# Altium schematic sheet Capstone_Schematic_24.SchDoc
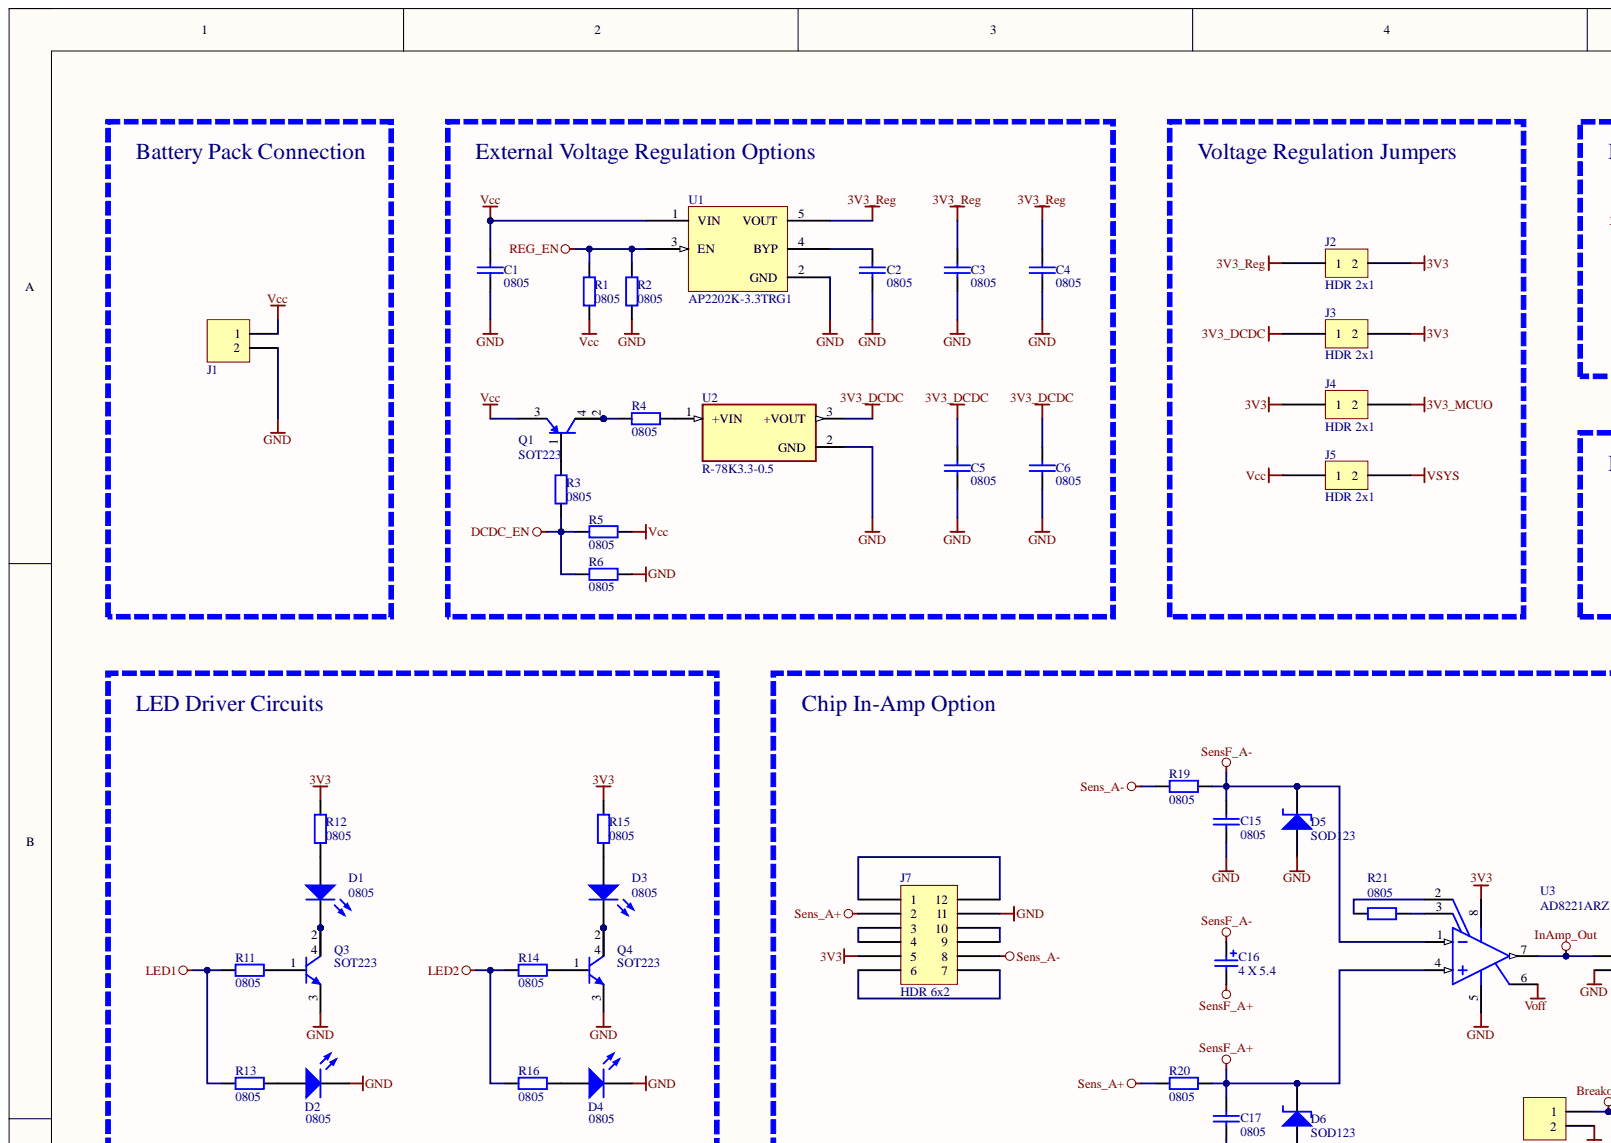
[diagram p0: Capstone_Schematic_24.SchDoc, top left]
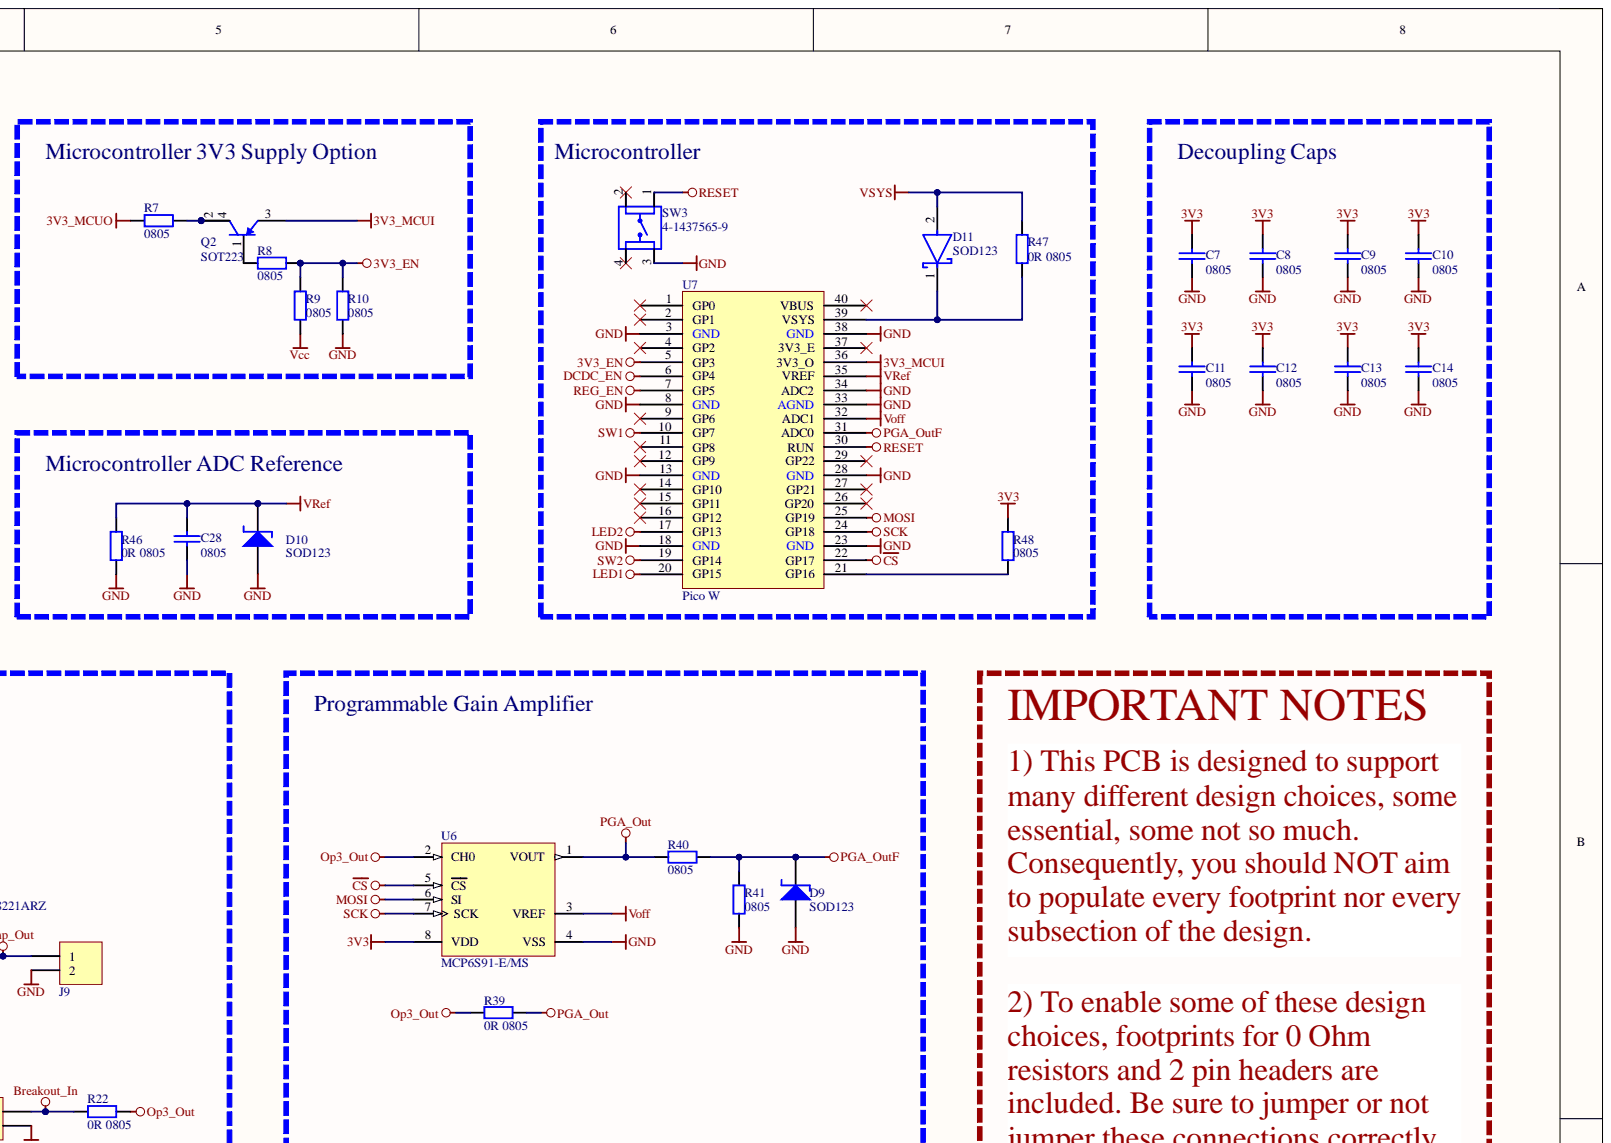
[diagram p1: Capstone_Schematic_24.SchDoc, top right]
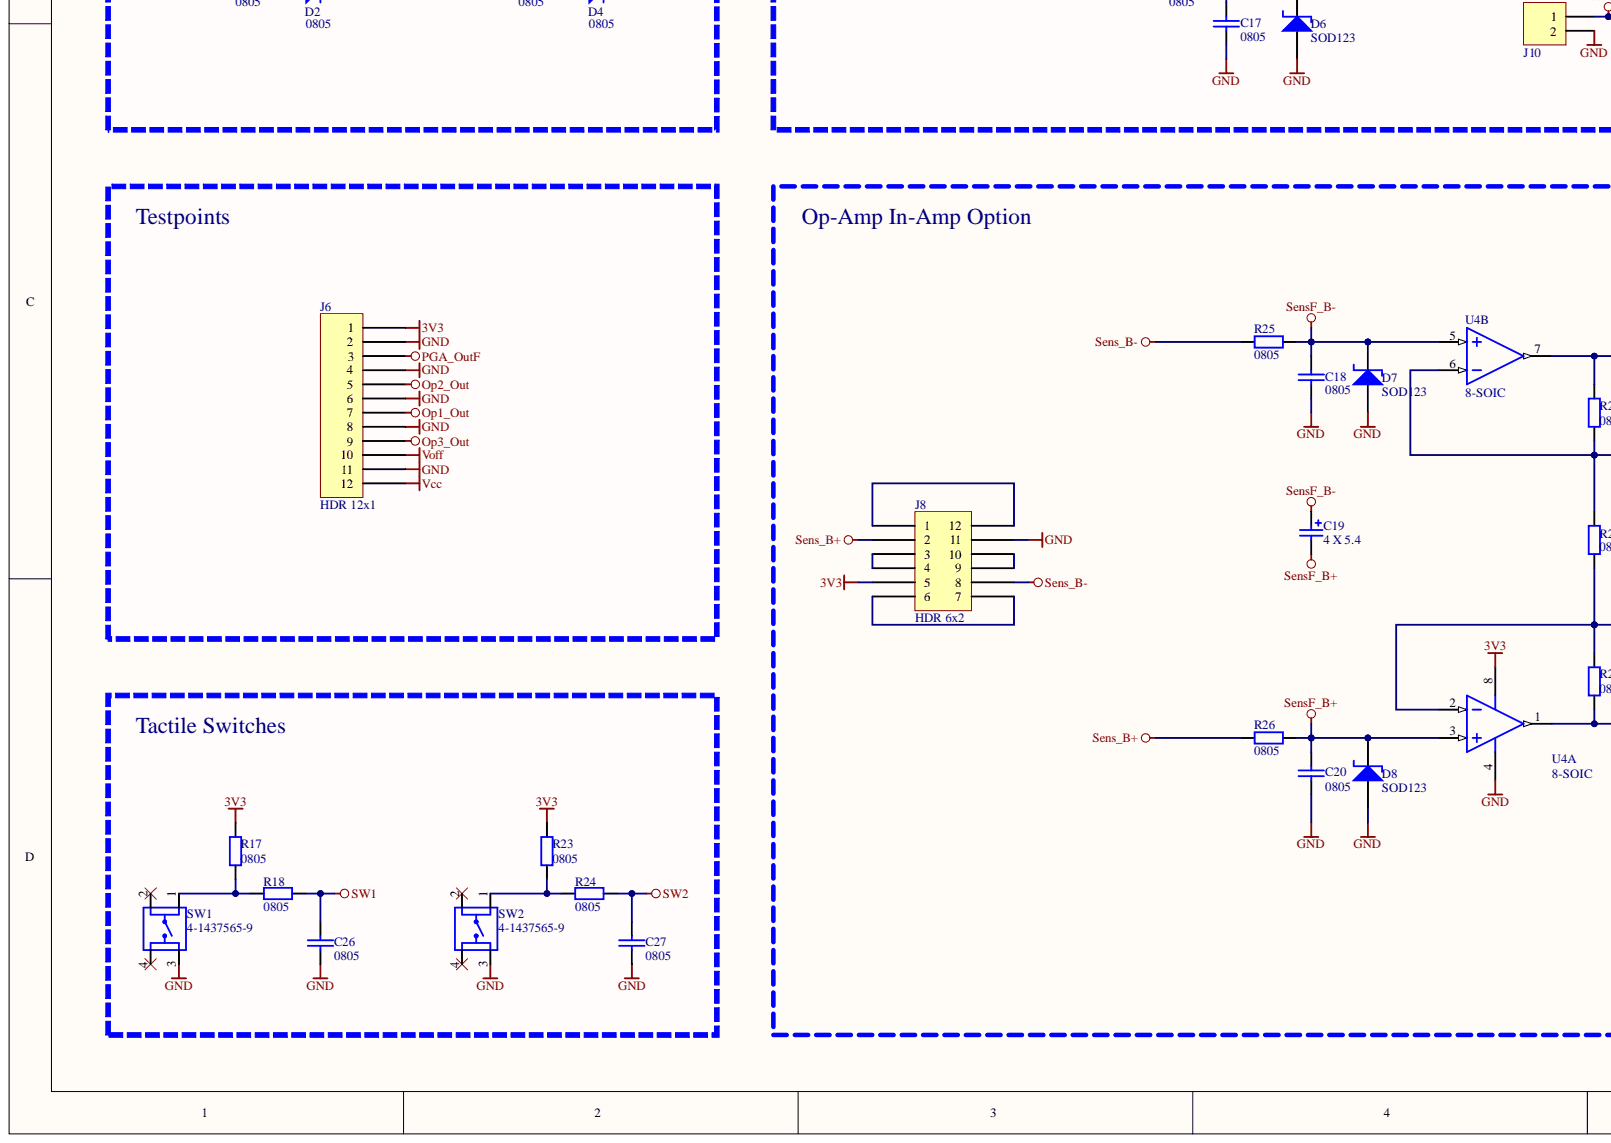
[diagram p2: Capstone_Schematic_24.SchDoc, bottom left]
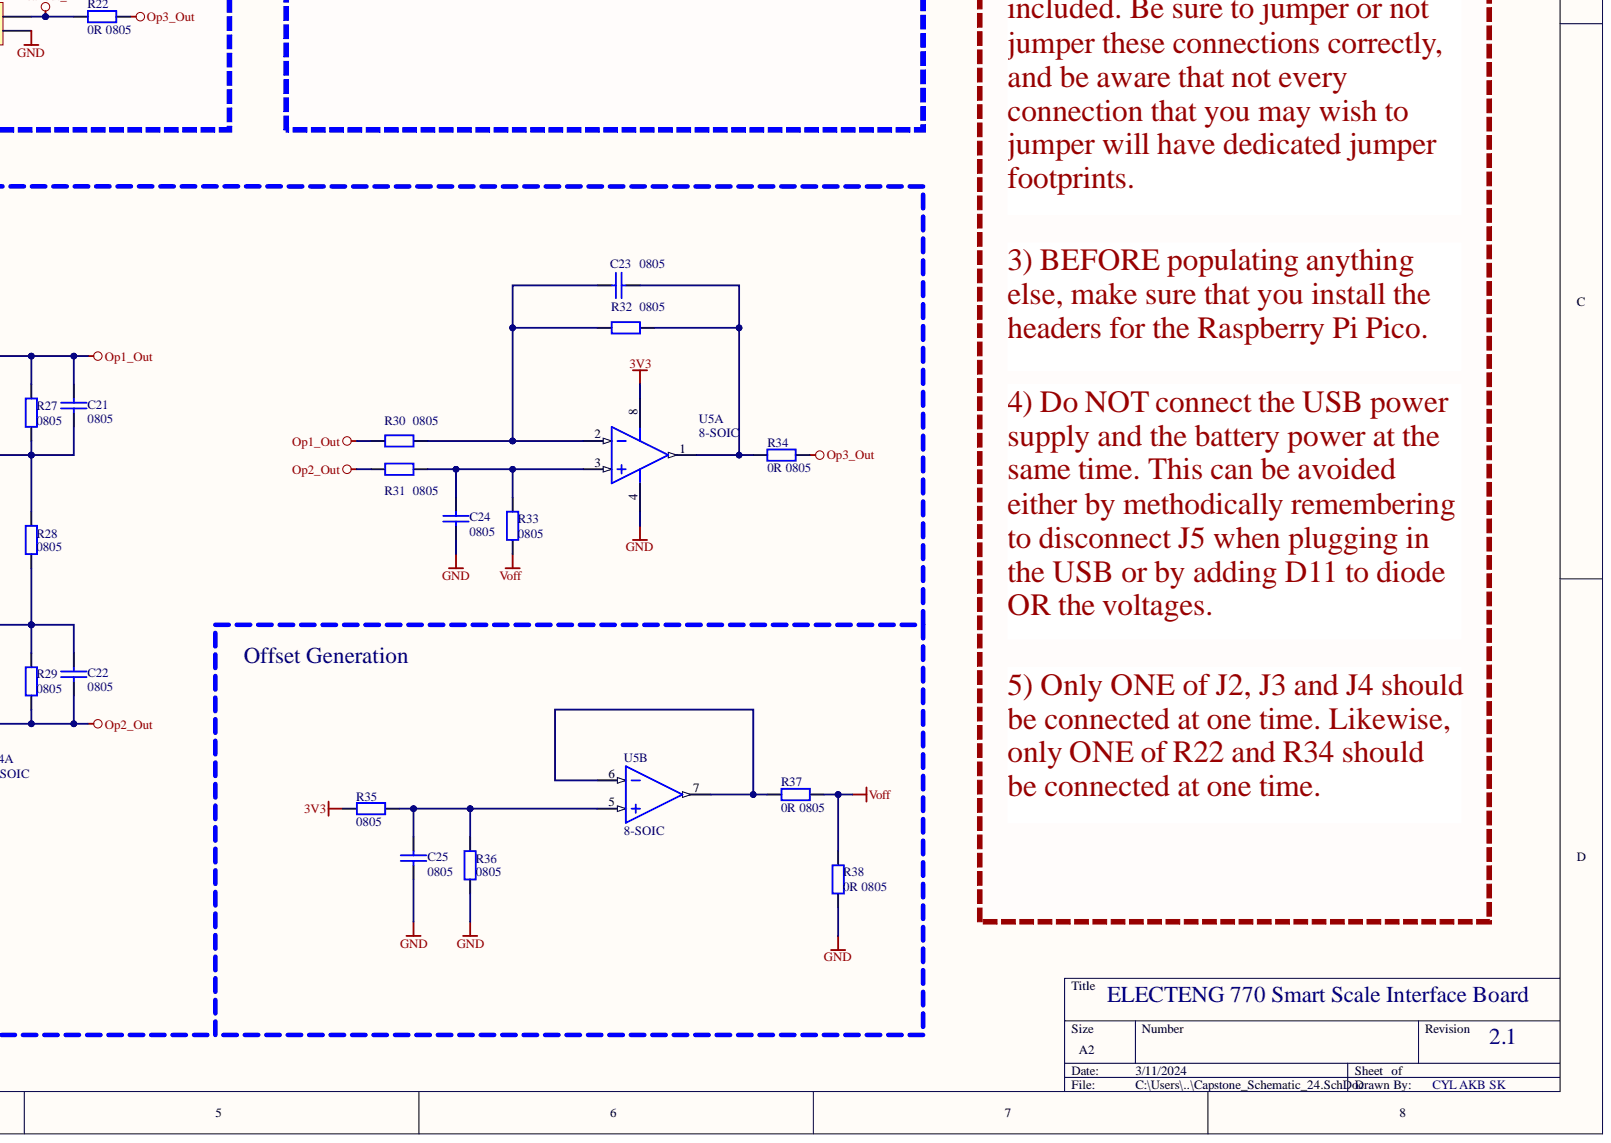
[diagram p3: Capstone_Schematic_24.SchDoc, bottom right]

## Parts
C1  0805  [0805 CAP]
C2  0805  [0805 CAP]
C3  0805  [0805 CAP]
C4  0805  [0805 CAP]
C5  0805  [0805 CAP]
C6  0805  [0805 CAP]
C7  0805  [0805 CAP]
C8  0805  [0805 CAP]
C9  0805  [0805 CAP]
C10  0805  [0805 CAP]
C11  0805  [0805 CAP]
C12  0805  [0805 CAP]
C13  0805  [0805 CAP]
C14  0805  [0805 CAP]
C15  0805  [0805 CAP]
C16  Size/Package: 4 X 5.4  [ECE-CAPAE4X5.4SM]  (hidden comment: 1uF)
C17  0805  [0805 CAP]
C18  0805  [0805 CAP]
C19  Size/Package: 4 X 5.4  [ECE-CAPAE4X5.4SM]  (hidden comment: 1uF)
C20  0805  [0805 CAP]
C21  0805  [0805 CAP]
C22  0805  [0805 CAP]
C23  0805  [0805 CAP]
C24  0805  [0805 CAP]
C25  0805  [0805 CAP]
C26  0805  [0805 CAP]
C27  0805  [0805 CAP]
C28  0805  [0805 CAP]
D1  0805  [0805 LED]
D2  0805  [0805 LED]
D3  0805  [0805 LED]
D4  0805  [0805 LED]
D5  SOD123  [ECE-DIO-SOD123SM]
D6  SOD123  [ECE-DIO-SOD123SM]
D7  SOD123  [ECE-DIO-SOD123SM]
D8  SOD123  [ECE-DIO-SOD123SM]
D9  SOD123  [ECE-DIO-SOD123SM]
D10  SOD123  [ECE-DIO-SOD123SM]
D11  SOD123  [FP-SOD123-MFG]
J1  2 Way  [ECE-600102STTH]  (value not drawn)
J2  HDR 2x1  [HDR 2x1]
J3  HDR 2x1  [HDR 2x1]
J4  HDR 2x1  [HDR 2x1]
J5  HDR 2x1  [HDR 2x1]
J6  HDR 12x1  [HDR 12x1]
J7  HDR 6x2  [HDR 6x2]
J8  HDR 6x2  [HDR 6x2]
J9  2 Way  [ECE-600102STTH]  (value not drawn)
J10  2 Way  [ECE-600102STTH]  (value not drawn)
Q1  SOT223  [ECE-SOT223SM]
Q2  SOT223  [ECE-SOT223SM]
Q3  SOT223  [ECE-SOT223SM]
Q4  SOT223  [ECE-SOT223SM]
R1  0805  [0805 RES]
R2  0805  [0805 RES]
R3  0805  [0805 RES]
R4  0805  [0805 RES]
R5  0805  [0805 RES]
R6  0805  [0805 RES]
R7  0805  [0805 RES]
R8  0805  [0805 RES]
R9  0805  [0805 RES]
R10  0805  [0805 RES]
R11  0805  [0805 RES]
R12  0805  [0805 RES]
R13  0805  [0805 RES]
R14  0805  [0805 RES]
R15  0805  [0805 RES]
R16  0805  [0805 RES]
R17  0805  [0805 RES]
R18  0805  [0805 RES]
R19  0805  [0805 RES]
R20  0805  [0805 RES]
R21  0805  [0805 RES]
R22  0R 0805  [0805 RES]
R23  0805  [0805 RES]
R24  0805  [0805 RES]
R25  0805  [0805 RES]
R26  0805  [0805 RES]
R27  0805  [0805 RES]
R28  0805  [0805 RES]
R29  0805  [0805 RES]
R30  0805  [0805 RES]
R31  0805  [0805 RES]
R32  0805  [0805 RES]
R33  0805  [0805 RES]
R34  0R 0805  [0805 RES]
R35  0805  [0805 RES]
R36  0805  [0805 RES]
R37  0R 0805  [0805 RES]
R38  0R 0805  [0805 RES]
R39  0R 0805  [0805 RES]
R40  0805  [0805 RES]
R41  0805  [0805 RES]
R46  0R 0805  [0805 RES]
R47  0R 0805  [0805 RES]
R48  0805  [0805 RES]
SW1  4-1437565-9  [TE_4-1437565-9]
SW2  4-1437565-9  [TE_4-1437565-9]
SW3  4-1437565-9  [TE_4-1437565-9]
U1  AP2202K-3.3TRG1  [AP2202]
U2  R-78K3.3-0.5  [CONV_R-78K3.3-0.5]
U3  AD8221ARZ  [ADI-R-8_M]
U4  8-SOIC  [SO - 8]  (parts A,B)
U5  8-SOIC  [SO - 8]  (parts A,B)
U6  MCP6S91-E/MS  [MSOP-MS8_M]
U7  Pico W  [MODULE_SC0918]

## Nets
3V3: C7.1 C8.1 C9.1 C10.1 C11.1 C12.1 C13.1 C14.1 J2.2 J3.2 J4.1 J6.1 J7.5 J8.5 R12.2 R15.2 R17.2 R23.2 R35.1 R48.2 U3.8 U4.8 U5.8 U6.8(VDD)
3V3_DCDC: C5.1 C6.1 J3.1 U2.3
3V3_EN: R8.1 R9.2 R10.2 U7.5(GP3)
3V3_MCUI: Q2.3 U7.36(3V3_O)
3V3_MCUO: J4.2 R7.2
3V3_Reg: C3.1 C4.1 J2.1 U1.5(VOUT)
Breakout_In: J10.1 R22.2
~{CS}: U6.5(CS) U7.22(GP17)
DCDC_EN: R3.1 R5.2 R6.1 U7.6(GP4)
GND: C1.2 C2.2 C3.2 C4.2 C5.2 C6.2 C7.2 C8.2 C9.2 C10.2 C11.2 C12.2 C13.2 C14.2 C15.2 C17.2 C18.2 C20.2 C24.2 C25.2 C26.2 C27.2 C28.2 D2.2 D4.2 D5.1 D6.1 D7.1 D8.1 D9.1 D10.1 J1.2 J6.2 J6.4 J6.6 J6.8 J6.11 J7.11 J8.11 J9.2 J10.2 Q3.3 Q4.3 R2.1 R6.2 R10.1 R36.1 R38.1 R41.1 R46.1 SW1.3 SW2.3 SW3.3 U1.2(GND) U2.2 U3.5 U4.4 U5.4 U6.4(VSS) U7.3(GND) U7.8(GND) U7.13(GND) U7.18(GND) U7.23(GND) U7.28(GND) U7.33(AGND) U7.34(ADC2) U7.38(GND)
InAmp_Out: J9.1 U3.7
LED1: R11.1 R13.1 U7.20(GP15)
LED2: R14.1 R16.1 U7.17(GP13)
MOSI: U6.6(SI) U7.25(GP19)
Op1_Out: C21.1 J6.7 R27.2 R30.1 U4.7
Op2_Out: C22.2 J6.5 R29.1 R31.1 U4.1
Op3_Out: J6.9 R22.1 R34.2 R39.2 U6.2(CH0)
PGA_Out: R39.1 R40.1 U6.1(VOUT)
PGA_OutF: D9.2 J6.3 R40.2 R41.2 U7.31(ADC0)
REG_EN: R1.2 R2.2 U1.3(EN) U7.7(GP5)
RESET: SW3.1 U7.30(RUN)
SCK: U6.7(SCK) U7.24(GP18)
Sens_A+: J7.2 R20.1
Sens_A-: J7.8 R19.1
Sens_B+: J8.2 R26.1
Sens_B-: J8.8 R25.1
SensF_A+: C16.2 C17.1 D6.2 R20.2 U3.4
SensF_A-: C15.1 C16.1 D5.2 R19.2 U3.1
SensF_B+: C19.2 C20.1 D8.2 R26.2 U4.3
SensF_B-: C18.1 C19.1 D7.2 R25.2 U4.5
SW1: C26.1 R18.2 U7.10(GP7)
SW2: C27.1 R24.2 U7.19(GP14)
Vcc: C1.1 J1.1 J5.1 J6.12 Q1.3 R1.1 R5.1 R9.1 U1.1(VIN)
Voff: J6.10 R33.1 R37.2 R38.2 U3.6 U6.3(VREF) U7.32(ADC1)
VRef: C28.1 D10.2 R46.2 U7.35(VREF)
VSYS: D11.2 J5.2 R47.2
NetC2_1: C2.1 U1.4(BYP)
NetC21_2: C21.2 R27.1 R28.2 U4.6
NetC22_1: C22.1 R28.1 R29.2 U4.2
NetC23_1: C23.1 R30.2 R32.1 U5.2
NetC23_2: C23.2 R32.2 R34.1 U5.1
NetC24_1: C24.1 R31.2 R33.2 U5.3
NetC25_1: C25.1 R35.2 R36.2 U5.5
NetD1_1: D1.1 R12.1
NetD1_2: D1.2 Q3.2 Q3.4
NetD2_1: D2.1 R13.2
NetD3_1: D3.1 R15.1
NetD3_2: D3.2 Q4.2 Q4.4
NetD4_1: D4.1 R16.2
NetD11_1: D11.1 R47.1 U7.39(VSYS)
NetJ7_1: J7.1 J7.12
NetJ7_3: J7.3 J7.4
NetJ7_6: J7.6 J7.7
NetJ7_9: J7.9 J7.10
NetJ8_1: J8.1 J8.12
NetJ8_3: J8.3 J8.4
NetJ8_6: J8.6 J8.7
NetJ8_9: J8.9 J8.10
NetQ1_1: Q1.1 R3.2
NetQ1_2: Q1.2 Q1.4 R4.2
NetQ2_1: Q2.1 R8.2
NetQ2_2: Q2.2 Q2.4 R7.1
NetQ3_1: Q3.1 R11.2
NetQ4_1: Q4.1 R14.2
NetR4_1: R4.1 U2.1
NetR17_1: R17.1 R18.1 SW1.1
NetR21_1: R21.1 U3.2
NetR21_2: R21.2 U3.3
NetR23_1: R23.1 R24.1 SW2.1
NetR37_1: R37.1 U5.6 U5.7
NetR48_1: R48.1 U7.21(GP16)

Not connected: SW1 2 4; SW2 2 4; SW3 2 4; U7 1(GP0) 2(GP1) 4(GP2) 9(GP6) 11(GP8) 12(GP9) 14(GP10) 15(GP11) 16(GP12) 26(GP20) 27(GP21) 29(GP22) 37(3V3_E) 40(VBUS)

## Library data of the parts
C1-C15 C17 C18 C20-C28 = CerCap_SMT_0805: SMT ceramic capacitor 0805 size; Component Kind: Standard; Library Name: Components.SchLib
C16 C19 = CAP000301: CAP 1uF 50V ELE SM; Manufactuer: Nichicon; Manufacturer-PN: UWX1H010MCL1GB; Assembly Method: Surface Mount; Database Table Name: Capacitos$; Item Type: Item; Path: ece/ts/Lists/Stock Inventory; Category: Capacitor; Component Kind: Standard; Component Type: CAP-4X5.4-1uF-50V; Datasheet Link: http://share.engineering.auckland.ac.nz/ece/ts/Student%20Documents/SMT-Ecaps-e-wx.pdf; Library Name: EceParts.DbLib; Revision: July-2002: Re-released for DXP Platform.; Status: Active; Supplier1: Digikey; Supplier1-PN: 493-2130-2-ND; UoA Stock#: CAP000301; Value: 1uF; Voltage/Current: 50V
D1-D4 = LED_SMT_0805: SMT LED 0805 size; Manufacturer: Lite-On Inc.; Manufacturer Part Number: LTST-C170KGKT; Color: Green; Current - Test: 20mA; Lens Color: Colorless; Lens Style/Size: Rectangle with Flat Top, 1.40mm x 1.25mm; Lens Transparency: Clear; Millicandela Rating: 35mcd; Viewing Angle: 130°; Voltage - Forward (Vf) (Typ): 2V; Wavelength - Dominant: 571nm; Wavelength - Peak: 574nm; Category: Optoelectronics; Component Kind: Standard; ComponentLink1Description: http://optoelectronics.liteon.com/upload/download/DS22-2000-073/LTST-C170KGKT.pdf; ComponentLink1URL: http://optoelectronics.liteon.com/upload/download/DS22-2000-073/LTST-C170KGKT.pdf; Features: -; Height (Max): 1.10mm; Library Name: Components.SchLib; Mounting Type: Surface Mount; Package / Case: 0805 (2012 Metric); Packaging: Cut Tape (CT); Part Status: Active; Pricing 1: 1=0.62, 10=0.459, 25=0.338, 100=0.2415, 250=0.16416, 500=0.14486, 1000=0.11347 (NZD); RoHS: RoHS Compliant; Size / Dimension: 2.00mm L x 1.25mm W; Stock 1: 503069; Supplier 1: Digi-Key; Supplier Part Number 1: 160-1414-1-ND
D5-D10 = ZEN000016: 3.3V Zener Diodes SM; Manufactuer: NXP Semiconductors; Manufacturer-PN: BZT52H-B3V3,115; Assembly Method: Surface Mount; Database Table Name: Zeners$; Item Type: Item; Path: ece/ts/Lists/Stock Inventory; Value: BZT52H-B3V3,115; Category: Zener; Component Kind: Standard; Library Name: EceParts.DbLib; Size/Package: SOD-123; Status: Active; Supplier1: Digikey; Supplier1-PN: 568-11141-6-ND; UoA Stock#: ZEN000016; Voltage/Current: 3.3V
D11 = CMP-12790-000026-1: DIODE SCHOTTKY 20V 500MA SOD123; Manufacturer: Diodes Inc.; Manufacturer Part Number: B0520LW-7-F; Capacitance: 170pF; Forward Current: 0.5A; Max Junction Temp: +125°C; Max Surge Forward Current: 5.5A; Peak Reverse Current: 250uA; Standoff Height: 0mm; Value: 170pF; Automotive: No; Category: Diode; Component Kind: Standard; ComponentLink2Description: Datasheet; ComponentLink2URL: https://www.diodes.com/assets/Datasheets/ds30051.pdf; Device Class L1: Discrete Semiconductors; Device Class L2: Diodes; Device Class L3: Schottky Diodes; Digikey Description: DIODE SCHOTTKY 20V 500MA SOD123; Footprint Url: https://www.diodes.com/assets/Package-Files/SOD123.pdf; Height: 1.45mm; Ipc Land Pattern Name: SOD365155X123-2; Lead Free: Yes; Library Name: Altium Content Vault; Max Repetitive Reverse Voltage: 20V; Mouser Description: Schottky Diodes & Rectifiers 20V 410mW; Octopart: https://octopart.com/b0520lw-7-f-diodes+inc.-325707; Package: SOD123; Reverse Voltage: 20V; RoHS: TRUE; Temperature: -65°C to +125°C; Temperature Range High: +125°C; Temperature Range Low: -65°C
J1 J9 J10 = CON000001: HEADER POLARISED 2WAY TH; Manufactuer: GTK; Manufacturer-PN: 600102ST; Assembly Method: Through hole; Database Table Name: Connectors$; Item Type: Item; Path: ece/ts/Lists/Stock Inventory; Category: Connector; Component Kind: Standard; Component Type: NA; Datasheet Link: http://share.engineering.auckland.ac.nz/ece/ts/Student%20Documents/polarised_connectors.pdf; Library Name: EceParts.DbLib; Size/Package: 2.54 Radial; Status: Active; Supplier1: Elecon; Supplier1-PN: YY3N02; Supplier2: Active Components; Supplier2-PN: 600102ST; UoA Stock#: CON000001; Value: 2 Way
Q1 Q2 = TRA000021: BCP53 PNP Transistor SM; Manufactuer: NXP Semiconductors; Manufacturer-PN: BCP53-16,115; Assembly Method: Surface Mount; Database Table Name: Transistors$; Item Type: Item; Path: ece/ts/Lists/Stock Inventory; Voltage/Current: 80V/1A; Category: Transistor; Component Kind: Standard; Component Type: TRA-SOT223-BCP53; Datasheet Link: http://share.engineering.auckland.ac.nz/ece/ts/Student%20Documents/BCP53_BCX53_BC53PA.pdf; Library Name: EceParts.DbLib; Size/Package: SOT-223; Status: Active; Supplier1: Digikey; Supplier1-PN: 568-6105-2-ND; UoA Stock#: TRA000021; Value: BCP53
Q3 Q4 = TRA000022: BCP56 NPN Transistor SM; Manufactuer: Diodes Inc; Manufacturer-PN: BCP5616TA; Assembly Method: Surface Mount; Database Table Name: Transistors$; Item Type: Item; Path: ece/ts/Lists/Stock Inventory; Voltage/Current: 80V/1A; Category: Transistor; Component Kind: Standard; Component Type: TRA-SOT223-BCP56; Datasheet Link: http://share.engineering.auckland.ac.nz/ece/ts/Student%20Documents/BCP56,BCX56.pdf; Library Name: EceParts.DbLib; Size/Package: SOT-223; Status: Active; Supplier1: Digikey; Supplier1-PN: BCP5616TATR-ND; UoA Stock#: TRA000022; Value: BCP56
R1-R41 R46-R48 = Res_SMT_0805: 0805 resistor; Component Kind: Standard; ComponentLink1Description: https://nz.mouser.com/datasheet/2/315/AOA0000C304-1149620.pdf; LatestRevisionDate: 17-Jul-2002; LatestRevisionNote: Re-released for DXP Platform.; Library Name: Components.SchLib; PackageReference: AXIAL-0.4; Published: 8-Jun-2000; Publisher: Altium Limited
SW1-SW3 = 4-1437565-9: Switch Tactile OFF _ON_ SPST Round Button Gull Wing 0.05A 12VDC 1.57N SMD Loose Piece; Configuration_Pole-Throw: Single Pole - Single Throw; Contact_Current_Rating: 50 mA; MF: TE Connectivity; MP: FSM6JSMA; Component Kind: Standard; Digi-Key_Part_Number: 450-1133-ND; Digi-Key_Purchase_URL: https://www.digikey.com/product-detail/en/te-connectivity-alcoswitch-switches/FSM6JSMA/450-1133-ND/525822?utm_source=snapeda&utm_medium=aggregator&utm_campaign=symbol; EU_RoHS_Compliance: Compliant; Library Name: 4-1437565-9.IntLib; Package: None; SnapEDA_Link: https://www.snapeda.com/parts/FSM6JSMA/TE%20Connectivity%20ALCOSWITCH%20Switches/view-part/522766/?ref=snap; TE_purchase_URL: https://www.te.com/usa-en/product-4-1437565-9.html?te_bu=Cor&te_type=disp&te_campaign=seda_glo_cor-seda-global-disp-prtnr-fy19-seda-model-bom-cta_sma-317_1&elqCampaignId=32493
U1 = AP2202: Component Kind: Standard; Library Name: Components.SchLib
U2 = R-78K3.3-0.5: 0.5A DC/DC-Converter 'INNOLINE' SIP3 reg; MF: Recom Power; Availability: In Stock; Check_prices: https://www.snapeda.com/parts/R-78K3.3-0.5/Recom+Power/view-part/?ref=eda; Component Kind: Standard; Library Name: R-78K3.3-0.5.IntLib; MP: R-78K3.3-0.5; Package: None; Price: None; Purchase-URL: https://pricing.snapeda.com/search/part/R-78K3.3-0.5/?ref=eda; SnapEDA_Link: https://www.snapeda.com/parts/R-78K3.3-0.5/Recom+Power/view-part/?ref=snap
U3 = CMP-0934-00045-1: Precision Instrumentation Amplifier, 2 V/us, -40 to 125 degC, 8-Pin SOIC (R-8), RoHS, Tube; Manufacturer: Analog Devices; -3dB Bandwidth@G: 1=825 kHz; Quiescent Current: 0.9 mA Typ.; Slew Rate@G: 1=2 V/us Typ.; VIO: 60 uV Max.; Component Kind: Standard; ComponentLink1Description: Manufacturer URL; ComponentLink1URL: http://www.analog.com/en/index.html; ComponentLink2Description: Datasheet; ComponentLink2URL: http://www.analog.com/static/imported-files/data_sheets/AD8221.pdf; ComponentLink3Description: Package Specification; ComponentLink3URL: http://www.analog.com/static/imported-files/packages/PKG_PDF/SOIC_Narrow%20(R)/R_8.pdf; DatasheetVersion: Rev. C; Library Name: Altium Content Vault; Mounting Technology: Surface Mount; PackageDescription: 8-Pin Standard Small Outline Package, Body 4.9 x 3.9 mm, Pitch 1.27 mm; PackageVersion: Jun-06; Packing: Tube; PartNumber: AD8221ARZ; RoHS: TRUE; Temperature Range: -40 to 125 degC
U4 U5 = LM358_SMT_SOIC8: IC OPAMP 8SOIC; Component Kind: Standard; ComponentLink1Description: http://www.ti.com/lit/ds/symlink/tlc080a.pdf; ComponentLink1URL: http://www.ti.com/lit/ds/symlink/tlc080a.pdf; Library Name: Components.SchLib; Supplier 1: Digi-Key; Supplier Part Number 1: 296-1014-1-ND
U6 = CMP-0187-00484-2: Single-Ended, Rail-to-Rail Input/Output, Low-Gain Programmable Gain Amplifier, 8-Pin MSOP, Extended Temperature; Component Kind: Standard; ComponentLink1Description: Manufacturer URL; ComponentLink1URL: http://www.microchip.com/; ComponentLink2Description: Datasheet; ComponentLink2URL: http://ww1.microchip.com/downloads/en/DeviceDoc/21908a.pdf; ComponentLink3Description: Package Specification; ComponentLink3URL: http://www.microchip.com/stellent/groups/techpub_sg/documents/packagingspec/en012702.pdf; DatasheetVersion: revA, Oct-2004; Library Name: Altium Content Vault; PackageDescription: 8-Lead Plastic Micro Small Outline Package (MS) [MSOP]; PackageReference: MSOP-MS8; PackageVersion: revBB, Aug-2009; RevisionNote: URLs added.
J2-J5 = HDR 2x1: Component Kind: Standard; Library Name: Components.SchLib
J6 = HDR 12x1: Component Kind: Standard; Library Name: Components.SchLib
J7 J8 = HDR 6x2: Component Kind: Standard; Library Name: Components.SchLib
U7 = Pico W: Component Kind: Standard; Library Name: Components.SchLib
